# Altium schematic sheet ChargeSupplies.SchDoc(ChargeSupplies)
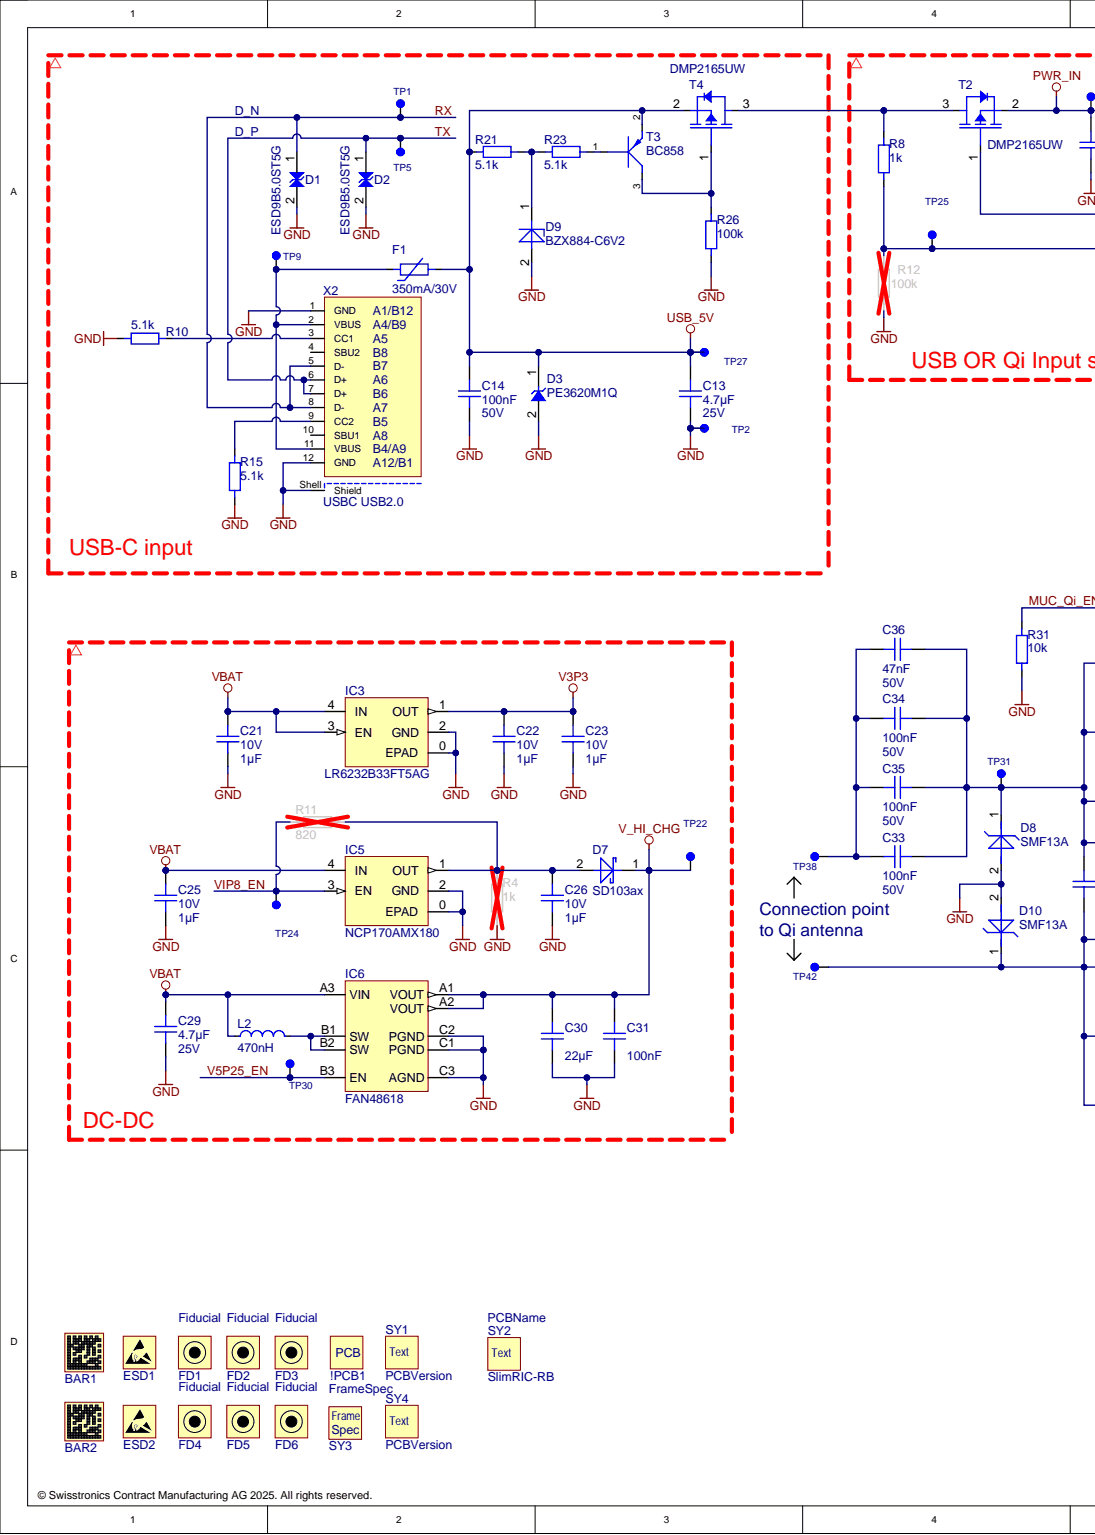
[diagram p0: ChargeSupplies.SchDoc, left]
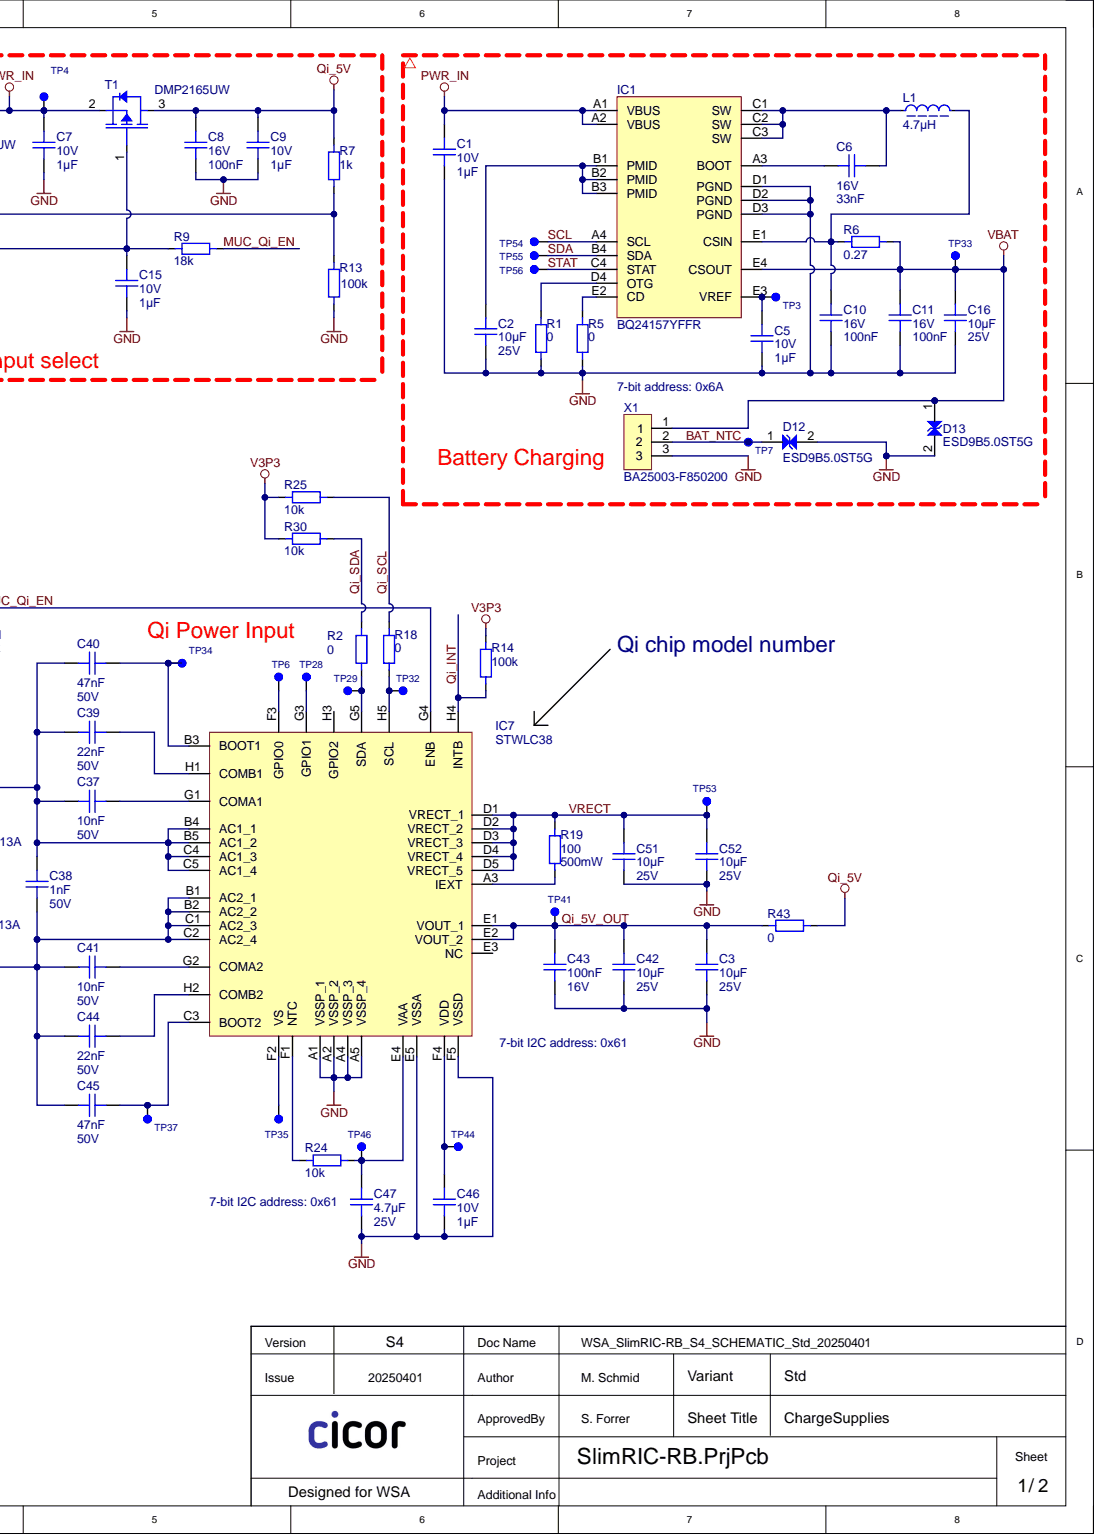
[diagram p1: ChargeSupplies.SchDoc, right]

## Parts
!PCB1  PCB  (mechanical)
BAR1  LaserBarcode  [LASERWITHREVISION_4.2X4.2]  (value not drawn)  (no BOM)
BAR2  LaserBarcode  [LASERWITHREVISION_4.2X4.2]  (value not drawn)  (no BOM)
C1  value 1µF  VoltageRated: 10V  [CAPC0603X02N-0201IPC]  (hidden comment: C_1uF_10V_20%_X5R_0201)
C2  value 10µF  VoltageRated: 25V  [CAPC1608X09N-0603IPC]  (hidden comment: C_10uF_25V_20%_X5R_0603)
C3  value 10µF  VoltageRated: 25V  [CAPC1608X09N-0603IPC]  (hidden comment: C_10uF_25V_20%_X5R_0603)
C5  value 1µF  VoltageRated: 10V  [CAPC0603X02N-0201IPC]  (hidden comment: C_1uF_10V_20%_X5R_0201)
C6  value 33nF  VoltageRated: 16V  [CAPC0603X02N-0201IPC]  (hidden comment: C_33nF_16V_20%_X5R_0201)
C7  value 1µF  VoltageRated: 10V  [CAPC0603X02N-0201IPC]  (hidden comment: C_1uF_10V_20%_X5R_0201)
C8  value 100nF  VoltageRated: 16V  [CAPC0603X02N-0201IPC]  (hidden comment: C_100nF_16V_10%_X5R_0201)
C9  value 1µF  VoltageRated: 10V  [CAPC0603X02N-0201IPC]  (hidden comment: C_1uF_10V_20%_X5R_0201)
C10  value 100nF  VoltageRated: 16V  [CAPC0603X02N-0201IPC]  (hidden comment: C_100nF_16V_10%_X5R_0201)
C11  value 100nF  VoltageRated: 16V  [CAPC0603X02N-0201IPC]  (hidden comment: C_100nF_16V_10%_X5R_0201)
C13  value 4.7µF  VoltageRated: 25V  [CAPC1608X09N-0603IPC]  (hidden comment: C_4.7uF_25V_20%_X5R_0603)
C14  value 100nF  VoltageRated: 50V  [CAPC1005X06N-0402IPC]  (hidden comment: C_100nF_50V_10%_X7R_0402)
C15  value 1µF  VoltageRated: 10V  [CAPC0603X02N-0201IPC]  (hidden comment: C_1uF_10V_20%_X5R_0201)
C16  value 10µF  VoltageRated: 25V  [CAPC1608X09N-0603IPC]  (hidden comment: C_10uF_25V_20%_X5R_0603)
C21  value 1µF  VoltageRated: 10V  [CAPC0603X02N-0201IPC]  (hidden comment: C_1uF_10V_20%_X5R_0201)
C22  value 1µF  VoltageRated: 10V  [CAPC0603X02N-0201IPC]  (hidden comment: C_1uF_10V_20%_X5R_0201)
C23  value 1µF  VoltageRated: 10V  [CAPC0603X02N-0201IPC]  (hidden comment: C_1uF_10V_20%_X5R_0201)
C25  value 1µF  VoltageRated: 10V  [CAPC0603X02N-0201IPC]  (hidden comment: C_1uF_10V_20%_X5R_0201)
C26  value 1µF  VoltageRated: 10V  [CAPC0603X02N-0201IPC]  (hidden comment: C_1uF_10V_20%_X5R_0201)
C29  value 4.7µF  VoltageRated: 25V  [CAPC1608X09N-0603IPC]  (hidden comment: C_4.7uF_25V_20%_X5R_0603)
C30  value 22µF  [CAPC1608X09N-0603IPC]  (hidden comment: C_22uF_10V_20%_X5R_0603)
C31  value 100nF  [CAPC0603X02N-0201IPC]  (hidden comment: C_100nF_16V_10%_X5R_0201)
C33  value 100nF  VoltageRated: 50V  [CAPC1005X06N-0402IPC]  (hidden comment: C_100nF_50V_10%_X7R_0402)
C34  value 100nF  VoltageRated: 50V  [CAPC1005X06N-0402IPC]  (hidden comment: C_100nF_50V_10%_X7R_0402)
C35  value 100nF  VoltageRated: 50V  [CAPC1005X06N-0402IPC]  (hidden comment: C_100nF_50V_10%_X7R_0402)
C36  value 47nF  [CAPC1005X06N-0402IPC]  (hidden comment: C_47nF_50V_10%_X7R_0402)
C37  value 10nF  VoltageRated: 50V  [CAPC1005X06N-0402IPC]  (hidden comment: C_10nF_50V_10%_X5R_0402)
C38  value 1nF  VoltageRated: 50V  [CAPC1005X06N-0402IPC]  (hidden comment: C_1nF_50V_10%_X7R_0402)
C39  value 22nF  VoltageRated: 50V  [CAPC1005X06N-0402IPC]  (hidden comment: C_22nF_50V_10%_X7R_0402)
C40  value 47nF  [CAPC1005X06N-0402IPC]  (hidden comment: C_47nF_50V_10%_X7R_0402)
C41  value 10nF  [CAPC1005X06N-0402IPC]  (hidden comment: C_10nF_50V_10%_X5R_0402)
C42  value 10µF  VoltageRated: 25V  [CAPC1608X09N-0603IPC]  (hidden comment: C_10uF_25V_20%_X5R_0603)
C43  value 100nF  VoltageRated: 16V  [CAPC0603X02N-0201IPC]  (hidden comment: C_100nF_16V_10%_X5R_0201)
C44  value 22nF  VoltageRated: 50V  [CAPC1005X06N-0402IPC]  (hidden comment: C_22nF_50V_10%_X7R_0402)
C45  value 47nF  VoltageRated: 50V  [CAPC1005X06N-0402IPC]  (hidden comment: C_47nF_50V_10%_X7R_0402)
C46  value 1µF  VoltageRated: 10V  [CAPC0603X02N-0201IPC]  (hidden comment: C_1uF_10V_20%_X5R_0201)
C47  value 4.7µF  VoltageRated: 25V  [CAPC1608X09N-0603IPC]  (hidden comment: C_4.7uF_25V_20%_X5R_0603)
C51  value 10µF  VoltageRated: 25V  [CAPC1608X09N-0603IPC]  (hidden comment: C_10uF_25V_20%_X5R_0603)
C52  value 10µF  VoltageRated: 25V  [CAPC1608X09N-0603IPC]  (hidden comment: C_10uF_25V_20%_X5R_0603)
D1  value ESD9B5.0ST5G  Manufacturer3PN: ESD9B5.0ST5G  [SOD-923]  (hidden comment: Onsemi_ESD9B5.0)
D2  value ESD9B5.0ST5G  Manufacturer3PN: ESD9B5.0ST5G  [SOD-923]  (hidden comment: Onsemi_ESD9B5.0)
D3  value PE3620M1Q  Manufacturer1PN: PE3620M1Q  [DFN65P100X50-2N]  (hidden comment: Panjit_PE3620M1Q)
D7  value SD103ax  [SOD-523]  (hidden comment: MCC_SD103AX)
D8  value SMF13A  Manufacturer1PN: SMF13A  [SOD-123W]  (hidden comment: Littlefuse_SMF13A)
D9  value BZX884-C6V2  [DFN65P100X50-2M]  (hidden comment: Nexperia_BZX884-C6V2,315)
D10  value SMF13A  Manufacturer1PN: SMF13A  [SOD-123W]  (hidden comment: Littlefuse_SMF13A)
D12  value ESD9B5.0ST5G  Manufacturer3PN: ESD9B5.0ST5G  [SOD-923]  (hidden comment: Onsemi_ESD9B5.0)
D13  value ESD9B5.0ST5G  Manufacturer3PN: ESD9B5.0ST5G  [SOD-923]  (hidden comment: Onsemi_ESD9B5.0)
ESD1  ESD-SYMBOL  [ESD-PCB_3mm]  (value not drawn)  (no BOM)
ESD2  ESD-SYMBOL  [ESD-PCB_3mm]  (value not drawn)  (no BOM)
F1  value 350mA/30V  [RESC3216N-1206IPC]  (hidden comment: BelFuse_0ZCJ0035AF2E)
FD1  value Fiducial  [FIDUCIAL-15-20]  (hidden comment: FIDUCIAL_Pin0)  (no BOM)
FD2  value Fiducial  [FIDUCIAL-15-20]  (hidden comment: FIDUCIAL_Pin0)  (no BOM)
FD3  value Fiducial  [FIDUCIAL-15-20]  (hidden comment: FIDUCIAL_Pin0)  (no BOM)
FD4  FIDUCIAL_Pin0  [FIDUCIAL-15-20]  (value not drawn)  (no BOM)
FD5  FIDUCIAL_Pin0  [FIDUCIAL-15-20]  (value not drawn)  (no BOM)
FD6  FIDUCIAL_Pin0  [FIDUCIAL-15-20]  (value not drawn)  (no BOM)
IC1  value BQ24157YFFR  Manufacturer1PN: BQ24157YFFR  [BGA-20]  (hidden comment: TI_BQ24157YFFR)
IC3  Manufacturer1PN: LR6232B33FT5AG  [4-XDFN Exposed Pad]  (hidden comment: Onsemi_NCP170AMX330TCG)
IC5  value NCP170AMX180  [4-XDFN Exposed Pad]  (hidden comment: onsemi_NCP170AMX180TCG)
IC6  value FAN48618  [9-WLCSP]  (hidden comment: Onsemi_FAN48618)
IC7  value STWLC38  [WLCSP40_SMDP]  (hidden comment: ST_STWLC38JRM)
L1  value 4.7µH  [INDC2518X20N]  (hidden comment: Taiyo_CB2518T4R7M)
L2  value 470nH  [INDC1608X095N-0603IPC]  (hidden comment: Murata_LQM18PNR47NFRL)
R1  value 0  [RESC0603X02N-0201IPC]  (hidden comment: R_0R_0.5A_0201)
R2  value 0  [RESC0603X02N-0201IPC]  (hidden comment: R_0R_0.5A_0201)
R4  value 1k  [RESC0603X02N-0201IPC]  (hidden comment: R_1k_50mW_200ppm_1%_0201)
R5  value 0  [RESC0603X02N-0201IPC]  (hidden comment: R_0R_0.5A_0201)
R6  value 0.27  [RESC1005X04N-0402IPC]  (hidden comment: R_270mR_63mW_200ppm_1%_0402)
R7  value 1k  [RESC0603X02N-0201IPC]  (hidden comment: R_1k_50mW_200ppm_1%_0201)
R8  value 1k  [RESC0603X02N-0201IPC]  (hidden comment: R_1k_50mW_200ppm_1%_0201)
R9  value 18k  [RESC0603X02N-0201IPC]  (hidden comment: R_18k_50mW_200ppm_1%_0201)
R10  value 5.1k  [RESC0603X02N-0201IPC]  (hidden comment: R_5.1k_50mW_200ppm_1%_0201)
R11  value 820  [RESC0603X02N-0201IPC]  (hidden comment: R_820R_50mW_200ppm_1%_0201)
R12  value 100k  [RESC0603X02N-0201IPC]  (hidden comment: R_100k_50mW_200ppm_1%_0201)
R13  value 100k  [RESC0603X02N-0201IPC]  (hidden comment: R_100k_50mW_200ppm_1%_0201)
R14  value 100k  [RESC0603X02N-0201IPC]  (hidden comment: R_100k_50mW_200ppm_1%_0201)
R15  value 5.1k  [RESC0603X02N-0201IPC]  (hidden comment: R_5.1k_50mW_200ppm_1%_0201)
R18  value 0  [RESC0603X02N-0201IPC]  (hidden comment: R_0R_0.5A_0201)
R19  value 100  PowerDissipation: 500mW  [RESC2012X065N-0805IPC]  (hidden comment: VishayDale_CRCW0805100RFKEAHP)
R21  value 5.1k  [RESC0603X02N-0201IPC]  (hidden comment: R_5.1k_50mW_200ppm_1%_0201)
R23  value 5.1k  [RESC0603X02N-0201IPC]  (hidden comment: R_5.1k_50mW_200ppm_1%_0201)
R24  value 10k  [RESC0603X02N-0201IPC]  (hidden comment: R_10k_50mW_200ppm_1%_0201)
R25  value 10k  [RESC0603X02N-0201IPC]  (hidden comment: R_10k_50mW_200ppm_1%_0201)
R26  value 100k  [RESC0603X02N-0201IPC]  (hidden comment: R_100k_50mW_200ppm_1%_0201)
R30  value 10k  [RESC0603X02N-0201IPC]  (hidden comment: R_10k_50mW_200ppm_1%_0201)
R31  value 10k  [RESC0603X02N-0201IPC]  (hidden comment: R_10k_50mW_200ppm_1%_0201)
R43  value 0  [RESC1005X04N-0402IPC]  (hidden comment: R_0R_1A_0402)
SY1  PCBVersion  [PCBVersion_1.0]  (no BOM)
SY2  SlimRIC-RB  value PCBName  [PCBName_1.0]  (no BOM)
SY3  FrameSpec  [FrameA3_Hor_Spec_75%]  (no BOM)
SY4  PCBVersion  [PCBVersion_1.0]  (no BOM)
T1  value DMP2165UW  [SOT65P210X110-3N]  (hidden comment: Diodes_DMP2165UW-7)
T2  value DMP2165UW  [SOT65P210X110-3N]  (hidden comment: Diodes_DMP2165UW-7)
T3  value BC858  [SOT65P210X110-3N]  (hidden comment: ONSemiconductor_BC858BWT1G)
T4  value DMP2165UW  [SOT65P210X110-3N]  (hidden comment: Diodes_DMP2165UW-7)
TP1  TestPoint  [TP_080mm]  (value not drawn)  (no BOM)
TP2  TestPoint  [TP_080mm]  (value not drawn)  (no BOM)
TP3  TestPoint  [TP_080mm]  (value not drawn)  (no BOM)
TP4  TestPoint  [TP_080mm]  (value not drawn)  (no BOM)
TP5  TestPoint  [TP_080mm]  (value not drawn)  (no BOM)
TP6  TestPoint  [TP_080mm]  (value not drawn)  (no BOM)
TP7  TestPoint  [TP_080mm]  (value not drawn)  (no BOM)
TP9  TestPoint  [TP_080mm]  (value not drawn)  (no BOM)
TP22  TestPoint  [TP_080mm]  (value not drawn)  (no BOM)
TP24  TestPoint  [TP_080mm]  (value not drawn)  (no BOM)
TP25  TestPoint  [TP_080mm]  (value not drawn)  (no BOM)
TP27  TestPoint  [TP_080mm]  (value not drawn)  (no BOM)
TP28  TestPoint  [TP_080mm]  (value not drawn)  (no BOM)
TP29  TestPoint  [TP_080mm]  (value not drawn)  (no BOM)
TP30  TestPoint  [TP_080mm]  (value not drawn)  (no BOM)
TP31  TestPoint  [TP_080mm]  (value not drawn)  (no BOM)
TP32  TestPoint  [TP_080mm]  (value not drawn)  (no BOM)
TP33  TestPoint  [TP_080mm]  (value not drawn)  (no BOM)
TP34  TestPoint  [TP_080mm]  (value not drawn)  (no BOM)
TP35  TestPoint  [TP_080mm-Without-3D]  (value not drawn)  (no BOM)
TP37  TestPoint  [TP_080mm]  (value not drawn)  (no BOM)
TP38  TestPoint  [TP_080mm]  (value not drawn)  (no BOM)
TP41  TestPoint  [TP_080mm]  (value not drawn)  (no BOM)
TP42  TestPoint  [TP_080mm]  (value not drawn)  (no BOM)
TP44  TestPoint  [TP_080mm]  (value not drawn)  (no BOM)
TP46  TestPoint  [TP_080mm]  (value not drawn)  (no BOM)
TP53  TestPoint  [TP_080mm]  (value not drawn)  (no BOM)
TP54  TestPoint  [TP_080mm]  (value not drawn)  (no BOM)
TP55  TestPoint  [TP_080mm]  (value not drawn)  (no BOM)
TP56  TestPoint  [TP_080mm]  (value not drawn)  (no BOM)
X1  value BA25003-F850200  Manufacturer1PN: BA25003-F850200  [B3B-PH-SM4-TB]  (hidden comment: ATOM_BA25003-F850200)
X2  value USBC USB2.0  [Atom_USB616FC-C2014256]  (hidden comment: Atom_USB616FC-C2014256)

## Nets
GND: C1.1 C2.1 C3.1 C5.1 C7.1 C8.1 C9.1 C10.1 C11.1 C13.1 C14.1 C15.1 C16.1 C21.1 C22.1 C23.1 C25.1 C26.1 C29.1 C30.1 C31.1 C42.1 C43.2 C46.1 C47.1 C51.1 C52.1 D1.2 D2.2 D3.2 D8.2 D9.2 D10.2 D12.2 D13.2 IC1.D1(PGND) IC1.D2(PGND) IC1.D3(PGND) IC3.0(EPAD) IC3.2(GND) IC5.0(EPAD) IC5.2(GND) IC6.C1(PGND) IC6.C2(PGND) IC6.C3(AGND) IC7.A1(VSSP_1) IC7.A2(VSSP_2) IC7.A4(VSSP_3) IC7.A5(VSSP_4) IC7.E5(VSSA) IC7.F5(VSSD) R1.1 R4.2 R5.1 R10.1 R12.1 R13.1 R15.1 R26.1 R31.1 TP2.1 X1.3 X2.1(GND) X2.12(GND) X2.Shell(Shield)
PWR_IN: C1.2 C7.2 IC1.A1(VBUS) IC1.A2(VBUS) T1.2 T2.2 TP4.1
Qi_5V: C8.2 C9.2 R7.2 R43.2 T1.3
USB_5V: C13.2 C14.2 D3.1 F1.2 R21.2 T3.2 T4.2 TP27.1
V3P3: C22.2 C23.2 IC3.1(OUT) R14.2 R25.2 R30.2
V_HI_CHG: C30.2 C31.2 D7.1 IC6.A1(VOUT) IC6.A2(VOUT) TP22.1
VBAT: C11.2 C16.2 C21.2 C25.2 C29.2 D13.1 IC1.E4(CSOUT) IC3.3(EN) IC3.4(IN) IC5.4(IN) IC6.A3(VIN) L2.1 R6.2 TP33.1 X1.1
BAT_NTC: D12.1 TP7.1 X1.2
MUC_Qi_EN: IC7.G4(ENB) R9.2 R31.2
Qi_5V_OUT: C3.2 C42.2 C43.1 IC7.E1(VOUT_1) IC7.E2(VOUT_2) R43.1 TP41.1
Qi_INT: IC7.H4(INTB) R14.1
Qi_SCL: R18.1 R25.1
Qi_SDA: R2.1 R30.1
RX: D1.1 TP1.1 X2.5(D-) X2.8(D-)
SCL: IC1.A4(SCL) TP54.1
SDA: IC1.B4(SDA) TP55.1
STAT: IC1.C4(STAT) TP56.1
TX: D2.1 TP5.1 X2.6(D+) X2.7(D+)
V5P25_EN: IC6.B3(EN) TP30.1
VIP8_EN: IC5.3(EN) R11.1 TP24.1
VRECT: C51.2 C52.2 IC7.D1(VRECT_1) IC7.D2(VRECT_2) IC7.D3(VRECT_3) IC7.D4(VRECT_4) IC7.D5(VRECT_5) R19.2 TP53.1
NetC2_2: C2.2 IC1.B1(PMID) IC1.B2(PMID) IC1.B3(PMID)
NetC5_2: C5.2 IC1.E3(VREF) TP3.1
NetC6_1: C6.1 IC1.C1(SW) IC1.C2(SW) IC1.C3(SW) L1.1
NetC6_2: C6.2 IC1.A3(BOOT)
NetC10_2: C10.2 IC1.E1(CSIN) L1.2 R6.1
NetC15_2: C15.2 R8.1 R9.1 R12.2 T1.1 TP25.1
NetC26_2: C26.2 D7.2 IC5.1(OUT) R4.1 R11.2
NetC33_1: C33.1 C34.1 C35.1 C36.2 TP38.1
NetC33_2: C33.2 C34.2 C35.2 C36.1 C37.1 C38.2 C39.1 C40.2 D8.1 IC7.B4(AC1_1) IC7.B5(AC1_2) IC7.C4(AC1_3) IC7.C5(AC1_4) TP31.1
NetC37_2: C37.2 IC7.G1(COMA1)
NetC38_1: C38.1 C41.1 C44.1 C45.2 D10.1 IC7.B1(AC2_1) IC7.B2(AC2_2) IC7.C1(AC2_3) IC7.C2(AC2_4) TP42.1
NetC39_2: C39.2 IC7.H1(COMB1)
NetC40_1: C40.1 IC7.B3(BOOT1) TP34.1
NetC41_2: C41.2 IC7.G2(COMA2)
NetC44_2: C44.2 IC7.H2(COMB2)
NetC45_1: C45.1 IC7.C3(BOOT2) TP37.1
NetC46_2: C46.2 IC7.F4(VDD) TP44.1
NetC47_2: C47.2 IC7.E4(VAA) R24.1 TP46.1
NetD9_1: D9.1 R21.1 R23.2
NetF1_1: F1.1 TP9.1 X2.2(VBUS) X2.11(VBUS)
NetIC1_D4: IC1.D4(OTG) R1.2
NetIC1_E2: IC1.E2(CD) R5.2
NetIC6_B1: IC6.B1(SW) IC6.B2(SW) L2.2
NetIC7_A3: IC7.A3(IEXT) R19.1
NetIC7_F1: IC7.F1(NTC) R24.2
NetIC7_F2: IC7.F2(VS) TP35.1
NetIC7_F3: IC7.F3(GPIO0) TP6.1
NetIC7_G3: IC7.G3(GPIO1) TP28.1
NetIC7_G5: IC7.G5(SDA) R2.2 TP29.1
NetIC7_H5: IC7.H5(SCL) R18.2 TP32.1
NetR7_1: R7.1 R13.2 T2.1
NetR8_2: R8.2 T2.3 T4.3
NetR10_2: R10.2 X2.3(CC1)
NetR15_2: R15.2 X2.9(CC2)
NetR23_1: R23.1 T3.1
NetR26_2: R26.2 T3.3 T4.1

Not connected: FD1 0; FD2 0; FD3 0; FD4 0; FD5 0; FD6 0; IC7 E3(NC) H3(GPIO2); X2 4(SBU2) 10(SBU1)

## Library data of the parts
!PCB1 = CMP-415-000009-12: V4; Manufacturer1: various; PartDescription: WSA SlimRIC-RB V4; Manufacturer1PN: various; Modified: 03.08.2017; PartNumber: PCB; PartNumberCicor: PCB; PartNumberR&D: PCB; Status: used; Library Name: SWT
BAR1 BAR2 = CMP-00078-13: Manufacturer1: various; Manufacturer1PN: various; Modified: 03.11.2022; PartNumber: LaserBarcode; PartNumberCicor: LaserBarcode; PartNumberR&D: LaserBarcode; Status: new; Library Name: SWT
C1 C5 C7 C9 C15 C21-C23 C25 C26 C46 = CMP-263-000197-11: CAP.CER 1uF 10V 20% X5R 0201; Manufacturer1: Samsung; Manufacturer1PN: CL03A105MP3ZSNH; Manufacturer2PN: CM03X5R105M10AH; TempRange: -55°C/+85°C; Type: Ceramic; Component Kind: Standard; Dielectric: X5R; Housing: 0201; Manufacturer2: Kyocera; Manufacturer3: Various; Manufacturer3PN: C_1uF_10V_20%_X5R_0201; Supplier1: Digi-Key; Supplier1PN: 1253-CM03X5R105M10AHCT-ND; Tolerance: 20%; Library Name: SWT
C2 C3 C16 C42 C51 C52 = CMP-00202-11: CAP.CER 10uF 25V X5R; Manufacturer1: Murata Electronics; Manufacturer1PN: GRM188R61E106MA73J; Manufacturer2PN: C1608X5R1E106M080AC; TempRange: -55°C/+85°C; Tolerance: 20%; Type: Ceramic; Component Kind: Standard; Dielectric: X5R; Housing: 0603; Manufacturer2: TDK; Manufacturer3: various; Manufacturer3PN: C_10uF_25V_20%_X5R_0603; PartNumber: C_10uF_25V_20%_X5R_0603; PartNumberCicor: C_10uF_25V_20%_X5R_0603; Library Name: SWT
C6 = CMP-00143-8: CAP.CER 33nF 16V X5R 0201; Manufacturer1: Taiyo Yuden; Manufacturer1PN: MSASE063SB5333MFNA01; Manufacturer2PN: GRM033R61C333ME84D; PartNumberCicor: 4129728; TempRange: -40°C/+85°C; Tolerance: 20%; Type: Ceramic; Component Kind: Standard; Dielectric: X5R; Housing: 0402; Manufacturer2: Murata Manufacturing Co.; Manufacturer3: Various; Manufacturer3PN: C_33nF_16V_20%_X5R_0201; PartDescription: CAP.CER 33nF 16V X5R 0201; PartNumber: C_33nF_16V_20%_X5R_0201; PartNumberR&D: C_33nF_16V_20%_X5R_0201; Status: checked&used; Library Name: SWT; Modified: 31.01.2023 FS; add possible sources 11.04.2023 SM
C8 C10 C11 C31 C43 = CMP-263-000020-11: CAP.CER 100nF 16V X5R 0201; Manufacturer1: Yageo; Manufacturer1PN: CC0201KRX5R7BB104; PartNumber: 4079999; PartNumberCicor: 100nF/16V/X5R/0603(0201)/10%; TempRange: -40°C/+85°C; Tolerance: 10%; Type: Ceramic; Component Kind: Standard; Dielectric: X5R; Housing: 0201; Manufacturer2: Various; Manufacturer2PN: C_100nF_16V_10%_X5R_0201; PartNumberR&D: C_100nF_16V_10%_X5R_0201; Library Name: SWT
  C31: VoltageRated: 16V
C13 C29 C47 = CMP-00457-3: Cap.Cer 4.7uF 25V X5R 0603; Manufacturer1: Murata Electronics; Manufacturer1PN: GRT188R61E475ME13D; TempRange: -55°C/+85°C; Tolerance: 20%; Type: Ceramic; Component Kind: Standard; Dielectric: X5R; Housing: 0603; Manufacturer2: various; Manufacturer2PN: C_4.7uF_25V_20%_X5R_0603; Library Name: SWT
C14 C33-C35 = CMP-263-000068-7: Cap.Cer,100nF,10%,50V,X7R,0402; Manufacturer1: Kyocera AVX; Manufacturer1PN: 04025C104KAT2A; Manufacturer2PN: C1005X7R1H104KT; Manufacturer3PN: GRM155R71H104KE14D; PartNumberCicor: 4061071; TempRange: -50°C/+125°C; Type: Ceramic; ClassName: Qi Power Input; Component Kind: Standard; Dielectric: X7R; Housing: 0402; Manufacturer2: TDK; Manufacturer3: MURATA MFG. CORP; Manufacturer4: various; Manufacturer4PN: C_100nF_50V_10%_X7R_0402; Tolerance: 10%; Library Name: SWT
C30 = CMP-263-000011-10: CAP.CER 22uF 10V; Manufacturer1: SAMSUNG; Manufacturer1PN: CL10A226MP8NUNE; Manufacturer2PN: C1608X5R1A226M080AC; PartNumber: 4079996; Dielectric: X5R; TempRange: -55°C/+85°C; Tolerance: 20%; Type: Ceramic; Component Kind: Standard; Housing: 0603; Manufacturer2: TDK ELECTRONICS CO. LTD.; Manufacturer3: various; Manufacturer3PN: C_22uF_10V_20%_X5R_0603; PartNumberCicor: 4079996; VoltageRated: 10V; Library Name: SWT
C36 C40 C45 = CMP-263-000010-10: CAP.CER 47nF 50V 10% X7R 0402; Manufacturer1: TDK; Manufacturer1PN: CGA2B3X7R1H473K050BB; PartNumber: 4078189; TempRange: -55°C/+125°C; Type: Ceramic; ClassName: Qi Power Input; Component Kind: Standard; Dielectric: X7R; Housing: 0402; Manufacturer2: various; Manufacturer2PN: C_47nF_50V_10%_X7R_0402; PartDescription: CAP.CER 47nF 50V 10% X7R 0402; PartNumberCicor: 4078189; Tolerance: 10%; Library Name: SWT
  C36 C40: VoltageRated: 50V
C37 = CMP-00456-1: 10 nF; Manufacturer1: Cal-Chip Electronics, Inc.; Manufacturer1PN: GMC04X5R103K50NT; PartDescription: CAP.CERAMIC 10N/50V 10% 0402; Dielectric: X5R; Tolerance: 10%; Type: Ceramic; ClassName: Qi Power Input; Component Kind: Standard; Housing: 0402; Manufacturer2: various; Manufacturer2PN: C_10nF_50V_10%_X5R_0402; Library Name: SWT
C38 = CMP-263-000105-8: CAP.CERAMIC 1N/50V 10% 0402; Manufacturer1: KEMET; Manufacturer1PN: C0402C102K5RAC; Manufacturer2PN: GRM155R71H102KA01D; PartNumber: 1488049; Dielectric: X7R; TempRange: -40°C/+125°C; ClassName: Qi Power Input; Component Kind: Standard; Housing: 0402; Manufacturer2: MURATA; Manufacturer3: various; Manufacturer3PN: C_1nF_50V_10%_X7R_0402; PartNumberCicor: 1488049; Supplier1: Digi-Key; Supplier1PN: 311-1352-1-ND; Tolerance: 10%; Type: Ceramic; Library Name: SWT
C39 C44 = CMP-263-000139-8: CAP CER 22nF 10% 50V X7R 0402; Manufacturer1: MURATA; Manufacturer1PN: GRM155R71H223KA12D; Manufacturer2PN: C0402C223K5RACTU; Manufacturer3PN: CL05B223KB5VPNC; Manufacturer4PN: UMK105B7223KVHF; Manufacturer5PN: CGJ2B3X7R1H223K050BB; PartNumber: 4061077; TempRange: -55°C/+125°C; Type: Ceramic; ClassName: Qi Power Input; Component Kind: Standard; Dielectric: X7R; Housing: 0402; Manufacturer2: KEMET; Manufacturer3: SAMSUNG; Manufacturer4: TAIYO YUDEN; Manufacturer5: TDK ELECTRONICS CO. LTD; Manufacturer6: various; Manufacturer6PN: C_22nF_50V_10%_X7R_0402; PartNumberCicor: 4061077; Supplier1: Digi-Key; Supplier1PN: 490-3884-1-ND; Tolerance: 10%; Library Name: SWT
C41 = CMP-00456-1: 10 nF; Manufacturer1: Cal-Chip Electronics, Inc.; Manufacturer1PN: GMC04X5R103K50NT; PartDescription: CAP.CERAMIC 10N/50V 10% 0402; Dielectric: X5R; Tolerance: 10%; Type: Ceramic; VoltageRated: 50V; ClassName: Qi Power Input; Component Kind: Standard; Housing: 0402; Manufacturer2: various; Manufacturer2PN: C_10nF_50V_10%_X5R_0402; Library Name: SWT
D1 D2 D12 D13 = CMP-00108-13: TVS DIODE 5VWM SOD923; Manufacturer1: LRC; Manufacturer1PN: LESD9D5.0CT5G; Manufacturer2PN: ESD9D5C; PartNumber: onsemi_ESD9B5.0ST5G; TempRange: -55°C/+150°C; VoltageBreakdown: 5.8V; VoltageRated: 5V; Availability: SiliconExpert 02.02.2023: 6.6 years; ClassName: USB-C input; Component Kind: Standard; ComponentLink1Description: Datasheet; ComponentLink1URL: \\CTCHFS01.cicor.local\epg$\EDA\Documents\Datasheets\ESD9B-D.PDF; ComponentLink2Description: Datasheet Web; ComponentLink2URL: https://www.onsemi.cn/pdf/datasheet/esd9b-d.pdf; ComponentLink3Description: Datasheet Leiditech; ComponentLink3URL: \\CTCHFS01.cicor.local\epg$\EDA\Documents\Datasheets\ESD9D5C.pdf; ComponentLink4Description: Datasheet Leiditech Web; ComponentLink4URL: https://de.leiditech.com/u_file/file/products/2212/16/86a2a579d2.pdf; ComponentLink5Description: Datasheet LRC; ComponentLink5URL: \\CTCHFS01.cicor.local\epg$\EDA\Documents\Datasheets\LRC_LESD9D5.0CT5G.pdf; Housing: SOD923; Manufacturer2: Leiditech; Manufacturer3: Onsemi; PartNumberCicor: onsemi_ESD9B5.0ST5G; Library Name: SWT
D3 = CMP-00200-10: Manufacturer1: Panjit; PartDescription: TVS DIODE 20V; CurrentRating: 8 A(8/20 uS); TempRange: -55°C-150°C; Type: TVS; VoltageRated: 20V; Availability: 2028; Component Kind: Standard; ComponentLink1Description: Datasheet; ComponentLink1URL: \\CTCHFS01.cicor.local\epg$\EDA\Documents\Datasheets\PE3620M1Q.pdf; ComponentLink2Description: Datasheet Web; ComponentLink2URL: https://www.panjit.com.tw/upload/datasheet/PE3620M1Q.pdf; Description: Panjit_PE3620M1Q; Housing: DFN65P100X50-2; PartNumber: Panjit_PE3620M1Q; PartNumberCicor: Panjit_PE3620M1Q; PartNumberR&D: Panjit_PE3620M1Q; Status: checked&used; Library Name: SWT; Modified: 12.04.2023 SM
D7 = CMP-00120-5: SD103AX; Manufacturer1: Micro Commercial Components; Manufacturer2PN: SD103AWX; PartDescription: DIO.SCHOTTKY 350mA 40V; CurrentRating: 350 mA; TempRange: -40°C/+125°C; VoltageRated: 40V; Availability: SiliconExpert 20.01.2023: 6 years; ClassName: DC-DC; Component Kind: Standard; ComponentLink1Description: Datasheet MCC; ComponentLink1URL: \\CTCHFS01.cicor.local\epg$\EDA\Documents\Datasheets\SD103AX_SOD_523_-2510832.pdf; ComponentLink2Description: Datasheet MCC Web; ComponentLink2URL: https://www.mccsemi.com/pdf/Products/SD103AX(SOD-523).PDF; ComponentLink3Description: Datasheet Yangji; ComponentLink3URL: \\CTCHFS01.cicor.local\epg$\EDA\Documents\Datasheets\Yangjie SD103AWX.pdf; ComponentLink4Description: Datasheet Yangji Web; ComponentLink4URL: https://www.21yangjie.com/ggs/xxh/xxhxtdejg/SD103AWX.pdf; Manufacturer1PN: SD103AX; Manufacturer2: Yangzhou Yangjie Electronic Technology Co., Ltd.; PartNumber: MCC_SD103AX; PartNumberCicor: MCC_SD103AX; PartNumberR&D: MCC_SD103AX; Status: checked&used; Library Name: SWT; Modified: 20.01.2023 JAA; 31.01.2023 FS; add source 10.02.2023 SM
D8 D10 = CMP-00590-1: TVS SOD123FL 13V 200W UNIDIR; Manufacturer2PN: SMF13A*; PowerDissipation: 1000W 8/20us 200W10/1000us; TempRange: -55°C/+150°C; VoltageBreakdown: 14.4V; ClassName: Qi Power Input; Component Kind: Standard; ComponentLink1Description: Datasheet; ComponentLink1URL: \\CTCHFS01.cicor.local\epg$\EDA\Documents\Datasheets\SMF.pdf; ComponentLink2Description: Datasheet Web; ComponentLink2URL: https://www.littelfuse.com/media?resourcetype=datasheets&itemid=28a4c224-f43f-411d-a08d-9fe49d690147&filename=tvs-smf-1103; Manufacturer1: Littlefuse; Manufacturer2: Various; PartDescription: TVS SOD123FL 13V 200W UNIDIR; VoltageRated: 13V; Library Name: SWT
D9 = CMP-004-00017-6: BZX884-C6V2,315; Manufacturer2PN: MM8Z6V2C; PartDescription: DIODE.ZENER 6.2V 250mW 5%; CurrentRating: 200mA; PowerDissipation: 250mW; TempRange: -55 / +150°C; Tolerance: 5%; VoltageRated: 6.2V; Availability: 2028; Component Kind: Standard; ComponentLink1Description: Datasheet; ComponentLink1URL: \\CTCHFS01.cicor.local\epg$\EDA\Documents\Datasheets\BZX884_BC_SER.pdf; ComponentLink2Description: Datasheet Web; ComponentLink2URL: https://assets.nexperia.com/documents/data-sheet/BZX884_BC_SER.pdf; ComponentLink3Description: Datasheet LGE; ComponentLink3URL: \\CTCHFS01.cicor.local\epg$\EDA\Documents\Datasheets\MM8Z2V0C-MM8Z75VC.pdf; Housing: DFN65P100X50-2; Manufacturer1: Nexperia; Manufacturer1PN: BZX884-C6V2,315; Manufacturer2: LGE; PartNumber: Nexperia_BZX884-C6V2,315; PartNumberCicor: Nexperia_BZX884-C6V2,315; PartNumberR&D: Nexperia_BZX884-C6V2,315; Status: used&checked; Library Name: SWT; Modified: 25.07.2023 SM / Review MN / add source 12.09.2023 SM
ESD1 ESD2 = CMP-415-000003-7: Comment; PartDescription: ESD-Symbol; PartNumber: ESD-SYMBOL; PartNumberCicor: ESD-SYMBOL; PartNumberR&D: ESD-SYMBOL; Status: new; Library Name: SWT
F1 = CMP-00216-4: Ih 350mA/30V; Manufacturer1: Bel Fuse Inc.; Manufacturer2PN: 1206L035/30WR; Manufacturer3PN: nSMD035-33V; PartDescription: Resettable Fuses - PTC 350mA/30V; CurrentRating: 350 mA; I_Trip: 750 mA; Type: Polyfuse; VoltageRated: 30 V; Component Kind: Standard; ComponentLink1Description: Datasheet; ComponentLink1URL: \\CTCHFS01.cicor.local\epg$\EDA\Documents\Datasheets\ds-cp-0zcj-series.pdf; ComponentLink2Description: Datasheet Web; ComponentLink2URL: https://www.belfuse.com/resources/datasheets/circuitprotection/ds-cp-0zcj-series.pdf; ComponentLink3Description: Datasheet Little; ComponentLink3URL: \\CTCHFS01.cicor.local\epg$\EDA\Documents\Datasheets\Littelfuse_PTC_1206L_Datasheet.pdf.pdf; ComponentLink4Description: Datasheet Little Weg; ComponentLink4URL: https://www.littelfuse.com/~/media/electronics/datasheets/resettable_ptcs/littelfuse_ptc_1206l_datasheet.pdf.pdf; ComponentLink5Description: Datasheet SEA&LAND; ComponentLink5URL: \\CTCHFS01.cicor.local\epg$\EDA\Documents\Datasheets\nSMD035-33V 新承认书 (002).pdf; Housing: RESC3216N-1206IPC; I_Hold: 350 mA; Manufacturer1PN: 0ZCJ0035AF2E; Manufacturer2: Littelfuse Inc.; Manufacturer3: SEA&LAND; PartNumber: BelFuse_0ZCJ0035AF2E; PartNumberCicor: BelFuse_0ZCJ0035AF2E; PartNumberR&D: BelFuse_0ZCJ0035AF2E; Status: new & checked; Library Name: SWT; Modified: 26.04.2023 SM / Review MN
FD1-FD6 = CMP-414-000002-5: Comment; PartDescription: FICUCIAL Round; PartNumber: FIDUCIAL_Pin0; PartNumberCicor: FIDUCIAL_Pin0; PartNumberR&D: FIDUCIAL_Pin0; Status: used; Library Name: SWT; Modified: 26.02.2021 JAA; 03.02.2023 no BOM SM
  FD4-FD6: Value: Fiducial
IC1 = CMP-00139-4: IC BATT CHG LI-ION 1CELL 20DSBGA; Manufacturer1: Texas Instruments; BatteryConfiguration: 1S; CurrentRating: 1.55A; TempRange: -30°C/+85°C; Uin: 6V; Availability: SiliconExpert 37.01.2023 ; 10 years; Component Kind: Standard; ComponentLink1Description: Datasheet; ComponentLink1URL: \\CTCHFS01.cicor.local\epg$\EDA\Documents\Datasheets\bq24157.pdf; ComponentLink2Description: DatasheetWeb; ComponentLink2URL: https://www.ti.com/product/BQ24157/part-details/BQ24157YFFR?keyMatch=BQ24157YFFR&tisearch=search-everything&usecase=OPN; PartDescription: IC BATT CHG LI-ION 1CELL 20DSBGA; PartNumber: TI_BQ24157YFFR; PartNumberCicor: TI_BQ24157YFFR; PartNumberR&D: TI_BQ24157YFFR; Status: checked&used; Type: Charger IC Lithium Ion/Polymer; Library Name: SWT; Modified: 27.01.2023 JAA; 31.01.2023 FS
IC3 = CMP-00105-15: LDO Regulator - Ultra‐Low IQ , CMOS, 150 mA; Manufacturer1: LRC; Manufacturer2PN: CJ6101B33F; PartDescription: IC REG LINEAR 3.3V 150mA 4XDFN; CurrentRating: 150mA; TempRange: -40°C/+85°C; Uin: 5.5 V; Uout: 3.3V; Value: NCP170; Availability: SiliconExpert 19.01.2023: 5 Years; ClassName: DC-DC; Component Kind: Standard; ComponentLink1Description: Datasheet JSJC; ComponentLink1URL: \\CTCHFS01.cicor.local\epg$\EDA\Documents\Datasheets\CJ6101 Series Rev-2.2.pdf; ComponentLink2Description: Datasheet JSJC Web; ComponentLink2URL: https://www.jscj-elec.com/gallery/file/CJ6101%20Series%20Rev-2.2.pdf; ComponentLink3Description: Datasheet; ComponentLink3URL: \\CTCHFS01.cicor.local\epg$\EDA\Documents\Datasheets\NCP170-D.PDF; ComponentLink4Description: Datasheet Web; ComponentLink4URL: https://www.onsemi.com/pdf/datasheet/ncp170-d.pdf; ComponentLink5Description: Datasheet LRC; ComponentLink5URL: \\CTCHFS01.cicor.local\epg$\EDA\Documents\Datasheets\LRC_LR6232.pdf; Housing: 4-XDFN; Manufacturer2: JSJC; Manufacturer3: Onsemi; Manufacturer3PN: NCP170AMX330TCG; PartNumber: onsemi_NCP170AMX330TCG; PartNumberCicor: onsemi_NCP170AMX330TCG; Type: Linear; Library Name: SWT
IC5 = CMP-00176-7: LDO Regulator - Ultra‐Low IQ , CMOS, 150 mA; Manufacturer1: onsemi; Manufacturer2PN: CJ6101B18F; PartDescription: IC REG LINEAR 1.8V 150mA 4XDFN; CurrentRating: 150mA; TempRange: -40°C/+85°C; Uin: 5.5 V; Uout: 1.8V; Availability: SiliconExpert 19.01.2023: 5 Years; ClassName: DC-DC; Component Kind: Standard; ComponentLink1Description: Datasheet JSJC; ComponentLink1URL: \\CTCHFS01.cicor.local\epg$\EDA\Documents\Datasheets\CJ6101 Series Rev-2.2.pdf; ComponentLink2Description: Datasheet JSJC Web; ComponentLink2URL: https://www.jscj-elec.com/gallery/file/CJ6101%20Series%20Rev-2.2.pdf; ComponentLink3Description: Datasheet; ComponentLink3URL: \\CTCHFS01.cicor.local\epg$\EDA\Documents\Datasheets\NCP170-D.PDF; ComponentLink4Description: Datasheet Web; ComponentLink4URL: https://www.onsemi.com/pdf/datasheet/ncp170-d.pdf; Housing: 4-XDFN; Manufacturer1PN: NCP170AMX180TCG; Manufacturer2: JSJC; PartNumber: onsemi_NCP170AMX180TCG; PartNumberCicor: onsemi_NCP170AMX180TCG; PartNumberR&D: onsemi_NCP170AMX180TCG; Status: checked&used; Type: Linear; Library Name: SWT; Modified: 07.03.2023 SM; add source 13.04.2023 SM
IC6 = CMP-00104-12: FAN48618; Manufacturer1: Onsemi; Manufacturer1PN: FAN48618BUC53X; PartDescription: IC REG BOOST 5.25V 1.2A 9WLCSP; CurrentRating: 1.2A; Frequency: 2.5MHz; TempRange: -40°C/+85°C; UinRange: 2.7 - 4.8V; Uout: 5.25V; Availability: SiliconExpert 19.01.2023: 5.5 years; 16.07.2024: 8.1 years; ClassName: DC-DC; Component Kind: Standard; ComponentLink1Description: Datasheet; ComponentLink1URL: \\CTCHFS01.cicor.local\epg$\EDA\Documents\Datasheets\FAN48618-D.PDF; ComponentLink2Description: DatasheetWeb; ComponentLink2URL: https://www.onsemi.com/download/data-sheet/pdf/fan48618-d.pdf; PartNumber: Onsemi_FAN48618; PartNumberCicor: Onsemi_FAN48618; PartNumberR&D: Onsemi_FAN48618; Status: checked&used; Type: Step-Up Regulator; Library Name: SWT; Modified: 19.01.2023 JAA; 19.01.2023 FS
IC7 = CMP-00451-17: Qi-compliant dual mode wireless power receiver for up to 15W; Manufacturer1: ST; CurrentRating: 2A; Uin max: 12V; Uin min: 5V; Uout: 5V typical, configurable; Component Kind: Standard; ComponentLink1Description: Datasheet; ComponentLink1URL: \\CTCHFS01.cicor.local\epg$\EDA\Documents\Datasheets\stwlc38.pdf; ComponentLink2Description: Datahseet Web; ComponentLink2URL: https://www.st.com/content/ccc/resource/technical/document/datasheet/group3/92/9e/21/71/9b/54/4e/22/DM00912846/files/DM00912846.pdf/jcr:content/translations/en.DM00912846.pdf; ComponentLink3Description: Datasheet V2.1.1; ComponentLink3URL: \\CTCHFS01.cicor.local\epg$\EDA\Documents\Datasheets\STWLC38_V2.1 1.pdf; Datasheet: \\CTCHFS01.cicor.local\epg$\EDA\Documents\Datasheets\stwlc38.pdf; Housing: WLCSP40; Manufacturer1PN: STWLC38JRM; Type: LDO/Buck/Boost..; Library Name: SWT
L1 = CMP-00140-5: Wire-wound Chip Power Inductor 4.7uH; Manufacturer1: Taiyo Yuden; Manufacturer2PN: LSQNA251818T4R7M; PartDescription: Wire-wound Chip Power Inductor 4.7uH 250mA; Irms: 1.1A; Isat: 340mA; Rmax: 0.169; TempRange: -40°C/+105°C; Tolerance: 20%; ClassName: Battery Charging; Component Kind: Standard; ComponentLink1Description: Datasheet; ComponentLink1URL: \\CTCHFS01.cicor.local\epg$\EDA\Documents\Datasheets\CB2518T4R7M_SS.pdf; ComponentLink2Description: Datasheet Web; ComponentLink2URL: https://media.digikey.com/pdf/Data%20Sheets/Taiyo%20Yuden%20PDFs%20URL%20links/CB2518T4R7M_SS.pdf; ComponentLink3Description: Datasheet new PN; ComponentLink3URL: \\CTCHFS01.cicor.local\epg$\EDA\Documents\Datasheets\LSQNA251818T4R7M_SS.pdf; ComponentLink4Description: Data Web new PN; ComponentLink4URL: https://ds.yuden.co.jp/TYCOMPAS/ap/detail?pn=LSQNA251818T4R7M&u=M; Manufacturer1PN: CB2518T4R7M; Manufacturer2: Taiyo Yuden; PartNumber: Taiyo_CB2518T4R7M; PartNumberCicor: Taiyo_CB2518T4R7M; PartNumberR&D: Taiyo_CB2518T4R7M; Type: Inductor; Library Name: SWT
L2 = CMP-00117-6: 470 nH 0603; Manufacturer1: Murata; PartDescription: IND.FIXED 470 nH +/-30% 1.1A 187.5mOHM 1608; Irms: 1.1A; Rmax: 0.1875; TempRange: -40°C/+85°C; Tolerance: 30%; Type: Inductor; Availability: SiliconExpert 02.02.2023: 7.5 years; ClassName: DC-DC; Component Kind: Standard; ComponentLink1Description: Datasheet; ComponentLink1URL: \\CTCHFS01.cicor.local\epg$\EDA\Documents\Datasheets\JELF243B_0033-1634182.pdf; ComponentLink2Description: DatasheetWeb; ComponentLink2URL: https://www.murata.com/en-eu/products/productdetail?partno=LQM18PNR47NFR%23; Manufacturer1PN: LQM18PNR47NFRL; PartNumber: Murata_LQM18PNR47NFRL; PartNumberCicor: Murata_LQM18PNR47NFRL; Library Name: SWT; Modified: 20.01.2023 JAA; 02.02.2023 FS
R1 R2 R5 R18 = CMP-232-000030-8: RES 0R 0201; Manufacturer1: PANASONIC (MATSUSHITA); Manufacturer1PN: ERJ-1GN0R00C; PartNumber: 4080046; Tolerance: 0%; Component Kind: Standard; Housing: 0201; Manufacturer2: various; Manufacturer2PN: R_0R_0.5A_0201; PartNumberCicor: 4080046; PartNumberR&D: R_0R_0.5A_0201; Library Name: SWT
R4 R7 R8 = CMP-232-000484-8: 1 k; Manufacturer1: Yageo; Manufacturer1PN: RC0201FR-071KL; PowerDissipation: 50mW; PPM: 200 ppm; Tolerance: 1%; Component Kind: Standard; Housing: 0201; Manufacturer2: various; Manufacturer2PN: R_1k_50mW_200ppm_1%_0201; PartNumberR&D: R_1k_50mW_200ppm_1%_0201; Library Name: SWT
R6 = CMP-00187-9: RES 270mR 0402; Manufacturer1: Susumu; Manufacturer1PN: RL0510S-R27-F; PowerDissipation: 63mW; PPM: 200ppm; Tolerance: 1%; Component Kind: Standard; ComponentLink1Description: Datasheet; ComponentLink1URL: \\CTCHFS01.cicor.local\epg$\EDA\Documents\Datasheets\n_catalog_partition08_en.pdf; ComponentLink2Description: Datasheet Web; ComponentLink2URL: https://www.susumu.co.jp/common/pdf/n_catalog_partition08_en.pdf; Housing: 0402; Manufacturer2: various; Manufacturer2PN: R_270mR_63mW_200ppm_1%_0402; PartNumberR&D: R_270mR_63mW_200ppm_1%_0402; Library Name: SWT
R9 = CMP-00148-9: RES 18k 50mW 200ppm 1% 0201; Manufacturer1: various; Manufacturer2PN: RC0201FR-0718KL; Component Kind: Standard; ComponentLink1Description: Datasheet; ComponentLink1URL: \\CTCHFS01.cicor.local\epg$\EDA\Documents\Datasheets\PYu-RC_Group_51_RoHS_L_12.pdf; Manufacturer1PN: R_18k_50mW_200ppm_1%_0201; Manufacturer2: YAGEO; PartDescription: RES 18k 50mW 200ppm 1% 0201; PartNumber: R_18k_50mW_200ppm_1%_0201; PartNumberCicor: R_18k_50mW_200ppm_1%_0201; PartNumberR&D: R_18k_50mW_200ppm_1%_0201; PowerDissipation: 50mW; PPM: 200ppm; Status: checked&used; Tolerance: 1%; Library Name: SWT; Modified: 03.02.2023 SM; 08.02.2023 KABE
R10 R15 R21 R23 = CMP-00121-8: RES 5.1k 50mW 200ppm 1% 0201; Manufacturer1: Panasonic Electronic Components; Manufacturer1PN: ERJ-1GNF5101C; Component Kind: Standard; Housing: 0201; Manufacturer2: Various; Manufacturer2PN: R_5.1k_50mW_200ppm_1%_0201; PartDescription: RES 5.1k 50mW 200ppm 1% 0201; PartNumber: R_5.1k_50mW_200ppm_1%_0201; PartNumberCicor: R_5.1k_50mW_200ppm_1%_0201; PartNumberR&D: R_5.1k_50mW_200ppm_1%_0201; PowerDissipation: 50mW; PPM: 200ppm; Status: new&checked; Tolerance: 1%; Library Name: SWT; Modified: 20.01.2023 JAA; 02.02.2023 FS
R11 = CMP-00136-5: RES 820R 50mW 200ppm 1% 0201; Manufacturer1: Panasonic; Manufacturer1PN: ERJ1GNF8200C; PartNumberCicor: 4087735; Component Kind: Standard; Housing: 0201; Manufacturer2: various; Manufacturer2PN: R_820R_50mW_200ppm_1%_0201; PartDescription: RES 820R 50mW 200ppm 1% 0201; PartNumber: R_820R_50mW_200ppm_1%_0201; PartNumberR&D: R_820R_50mW_200ppm_1%_0201; PowerDissipation: 50mW; PPM: 200ppm; Status: new&checked; Tolerance: 1%; Library Name: SWT; Modified: 26.01.2023 SM
R12-R14 R26 = CMP-232-000486-8: RES 100k 50mW 200ppm 1% 0201; Manufacturer1: Bourns; Manufacturer1PN: CR0201-FW-1003GLF; Component Kind: Standard; Housing: 0201; Manufacturer2: various; Manufacturer2PN: R_100k_50mW_200ppm_1%_0201; PartNumberCicor: R_100k_50mW_200ppm_1%_0201; PartNumberR&D: R_100k_50mW_200ppm_1%_0201; PowerDissipation: 50mW; PPM: 200 ppm; Tolerance: 1%; Library Name: SWT
  R12 R13: ClassName: USB OR Qi Input select
  R14: ClassName: Qi Power Input
  R26: ClassName: USB-C input
R19 = CMP-00465-3: RES SMD 100 OHM 1% 1/2W 0805; Manufacturer2PN: SG73P2ATTD1000F; PPM: 100 ppm; ClassName: Qi Power Input; Component Kind: Standard; Housing: 0805; Manufacturer1: Vishay Dale; Manufacturer1PN: CRCW0805100RFKEAHP; Manufacturer2: KOA Speer Electronics; Tolerance: 1%; Library Name: SWT
R24 R25 R30 R31 = CMP-232-000108-9: RES 10k 50mW 200ppm 1% 0201; Manufacturer1: Stackpole Electronics; Manufacturer1PN: RMCF0201FT10K0; PartNumber: 4061069; Component Kind: Standard; Housing: 0201; Manufacturer2: various; Manufacturer2PN: R_10k_50mW_200ppm_1%_0201; PartNumberCicor: 4061069; PartNumberR&D: R_10k_50mW_200ppm_1%_0201; PowerDissipation: 50mW; PPM: 200 ppm; Tolerance: 1%; Library Name: SWT
R43 = CMP-232-000055-14: 0 R; Manufacturer1: YAGEO CORPORATION; Manufacturer1PN: RC0402JR-070RL; Manufacturer2PN: CRCW04020000Z0ED; Manufacturer3PN: ERJ2GE0R00X; PartNumberCicor: 1463343; PowerDissipation: 63mW; Component Kind: Standard; ComponentLink1Description: Datasheet; ComponentLink1URL: \\CTCHFS01.cicor.local\epg$\EDA\Documents\Datasheets\; Manufacturer2: VISHAY INTERTECHNOLOGY,INC; Manufacturer3: PANASONIC (MATSUSHITA); Manufacturer4: various; Manufacturer4PN: R_0R_1A_0402; PartNumberR&D: R_0R_1A_0402; Supplier1: Digi-Key; Supplier1PN: RHM0.0JCT-ND; Library Name: SWT
SY1 SY4 = CMP-415-000017-6: PCB.Vers; PartDescription: Text im Kupfer mit Variable PCBVersion; PartNumber: PCBVersion; PartNumberCicor: PCBVersion; PartNumberR&D: PCBVersion; Status: used; Library Name: SWT; Modified: 19.10.2023 MUL
SY2 = CMP-415-000015-5: PCB.Name; PartDescription: Text im Kupfer mit Variable PCBName; Status: used; Library Name: SWT; Modified: 19.10.2023 MUL
SY3 = CMP-00631-5: WSA_SlimRIC-RB_V4_PCB-Spezifications_; DocNameText: WSA_SlimRIC-RB_V4_PCB-Spezifications_; PartNumber: FrameSpec; PartNumberCicor: FrameSpec; PartNumberR&D: FrameSpec; Value: FrameSpec; Library Name: SWT
T1 T2 T4 = CMP-00111-6: PMF170XP,115; Manufacturer1: Diodes; Manufacturer2PN: PJC7407_R1_00001; Manufacturer4PN: YJL2101W; PartDescription: TRN.MOSFET P FDN304P; CurrentRating: -1A; RDS_ON: 0.2; RDS_ON_@: 200mR@-4.5V/1A; TempRange: -55°C/+150°C; Type: P; VoltageRated: -20V; Availability: SiliconExpert 19.01.2023: 6.4 years - 8 years; Component Kind: Standard; ComponentLink1Description: Datasheet Nexperia; ComponentLink1URL: \\CTCHFS01.cicor.local\epg$\EDA\Documents\Datasheets\PMF170XP.pdf; ComponentLink2Description: Datasheet Nexperia Web; ComponentLink2URL: https://assets.nexperia.com/documents/data-sheet/PMF170XP.pdf; ComponentLink3Description: Datasheet Panjit; ComponentLink3URL: \\CTCHFS01.cicor.local\epg$\EDA\Documents\Datasheets\PJC7407.pdf; ComponentLink4Description: Datasheet Panjit Web; ComponentLink4URL: https://www.panjit.com.tw/upload/datasheet/PJC7407.pdf; ComponentLink5Description: Datasheet Diodes; ComponentLink5URL: \\CTCHFS01.cicor.local\epg$\EDA\Documents\Datasheets\DMP2165UW.pdf; ComponentLink6Description: Datasheet Diodes Web; ComponentLink6URL: https://www.diodes.com/assets/Datasheets/DMP2165UW.pdf; ComponentLink7Description: Datasheet Yangjie; ComponentLink7URL: \\CTCHFS01.cicor.local\epg$\EDA\Documents\Datasheets\Yangjie YJL2101W.pdf; ComponentLink8Description: Datasheet Yangjie Web; ComponentLink8URL: https://www.21yangjie.com/ggs/mosfet/zdymosfet/YJL2101W.pdf; Housing: SOT65P210X110; Manufacturer1PN: DMP2165UW-7; Manufacturer2: Panjit International Inc.; Manufacturer3: Nexperia USA Inc.; Manufacturer3PN: PMF170XP,115; Manufacturer4: Yangzhou Yangjie Electronic Technology Co., Ltd.; Library Name: SWT; Modified: 19.01.2023 SM; 02.02.2023 FS; add source 10.02.2023 SM
  T1 T2: ClassName: USB OR Qi Input select
  T4: ClassName: USB-C input
T3 = CMP-00207-4: BC858BWT1G; Manufacturer2PN: BC858 (A, B or C); PartDescription: TRN.DIV BC858; CurrentRating: 100 mA; PowerDissipation: 150 mW; TempRange: -55°C/+150°C; Type: PNP; VoltageRated: -30 V; ClassName: USB-C input; Component Kind: Standard; ComponentLink1Description: Datasheet; ComponentLink1URL: \\CTCHFS01.cicor.local\epg$\EDA\Documents\Datasheets\BC856BWT1-D.PDF; ComponentLink2Description: Datasheet Web; ComponentLink2URL: https://www.onsemi.com/pdf/datasheet/bc856bwt1-d.pdf; Manufacturer1: ON Semiconductor; Manufacturer1PN: BC858BWT1G; Manufacturer2: various; PartNumber: ONSemiconductor_BC858BWT1G; PartNumberCicor: ONSemiconductor_BC858BWT1G; PartNumberR&D: ONSemiconductor_BC858BWT1G; Status: new & checked; Library Name: SWT; Modified: 14.04.2023 SM
TP1-TP7 TP9 TP22 TP24 TP25 TP27-TP35 TP37 TP38 TP41 TP42 TP44 TP46 TP53-TP56 = CMP-370-000002-9: TP; PartNumber: TP_mini; Manufacturer1: -; Manufacturer1PN: -; PartNumberCicor: TP_mini; PartNumberR&D: TP_mini; Value: TP; Library Name: SWT
X1 = CMP-00670-2: Battery connector 3pin; Manufacturer1: ATOM; Contacts: 3; Current: 2A; VoltageRated: 12V; Availability: Customer specific; Component Kind: Standard; ComponentLink1Description: Datasheet; ComponentLink1URL: \\CTCHFS01.cicor.local\epg$\EDA\Documents\Datasheets\ATOM_BA25003-F850200.pdf; ComponentLink2Description: Datasheet Web; Library Name: SWT
X2 = CMP-00701-1: USBC USB2.0; Manufacturer1: Shenzhen Atom Technology Co., Ltd.; PartDescription: USBC connector with USB2.0 signaling; Contacts: 12; Current: 5A; Note: Second footprint is for compatibility reason with ATOM_USB616FC-C2014226; VoltageRated: 20V; Component Kind: Standard; ComponentLink1Description: Datasheet; ComponentLink1URL: \\CTCHFS01.cicor.local\epg$\EDA\Documents\Datasheets\USB616FC-C2014256Shellchanged.pdf; ComponentLink2Description: Datasheet Web; ComponentLink2URL: https://www.atom-connector.com/uploads/USB-TYPE-C-16P-FEMALE-SMT-%E5%8D%95%E6%8E%92-%E5%B8%A6%E6%9F%B1-%E5%A4%96%E5%A3%B3%E5%9B%9B%E8%84%9A%E6%8F%92%E6%9D%BF-L7.35%EF%BC%88%E7%94%B5%E6%B5%815A%EF%BC%8C%E7%9B%90%E9%9B%BE48H%EF%BC%89-USB616FC-C2014216-ATOM-A05877.pdf; Manufacturer1PN: USB616FC-C2014256; PartNumberR&D: Atom_USB616FC-C2014256; Library Name: SWT
